# Altium schematic sheet Power.SchDoc
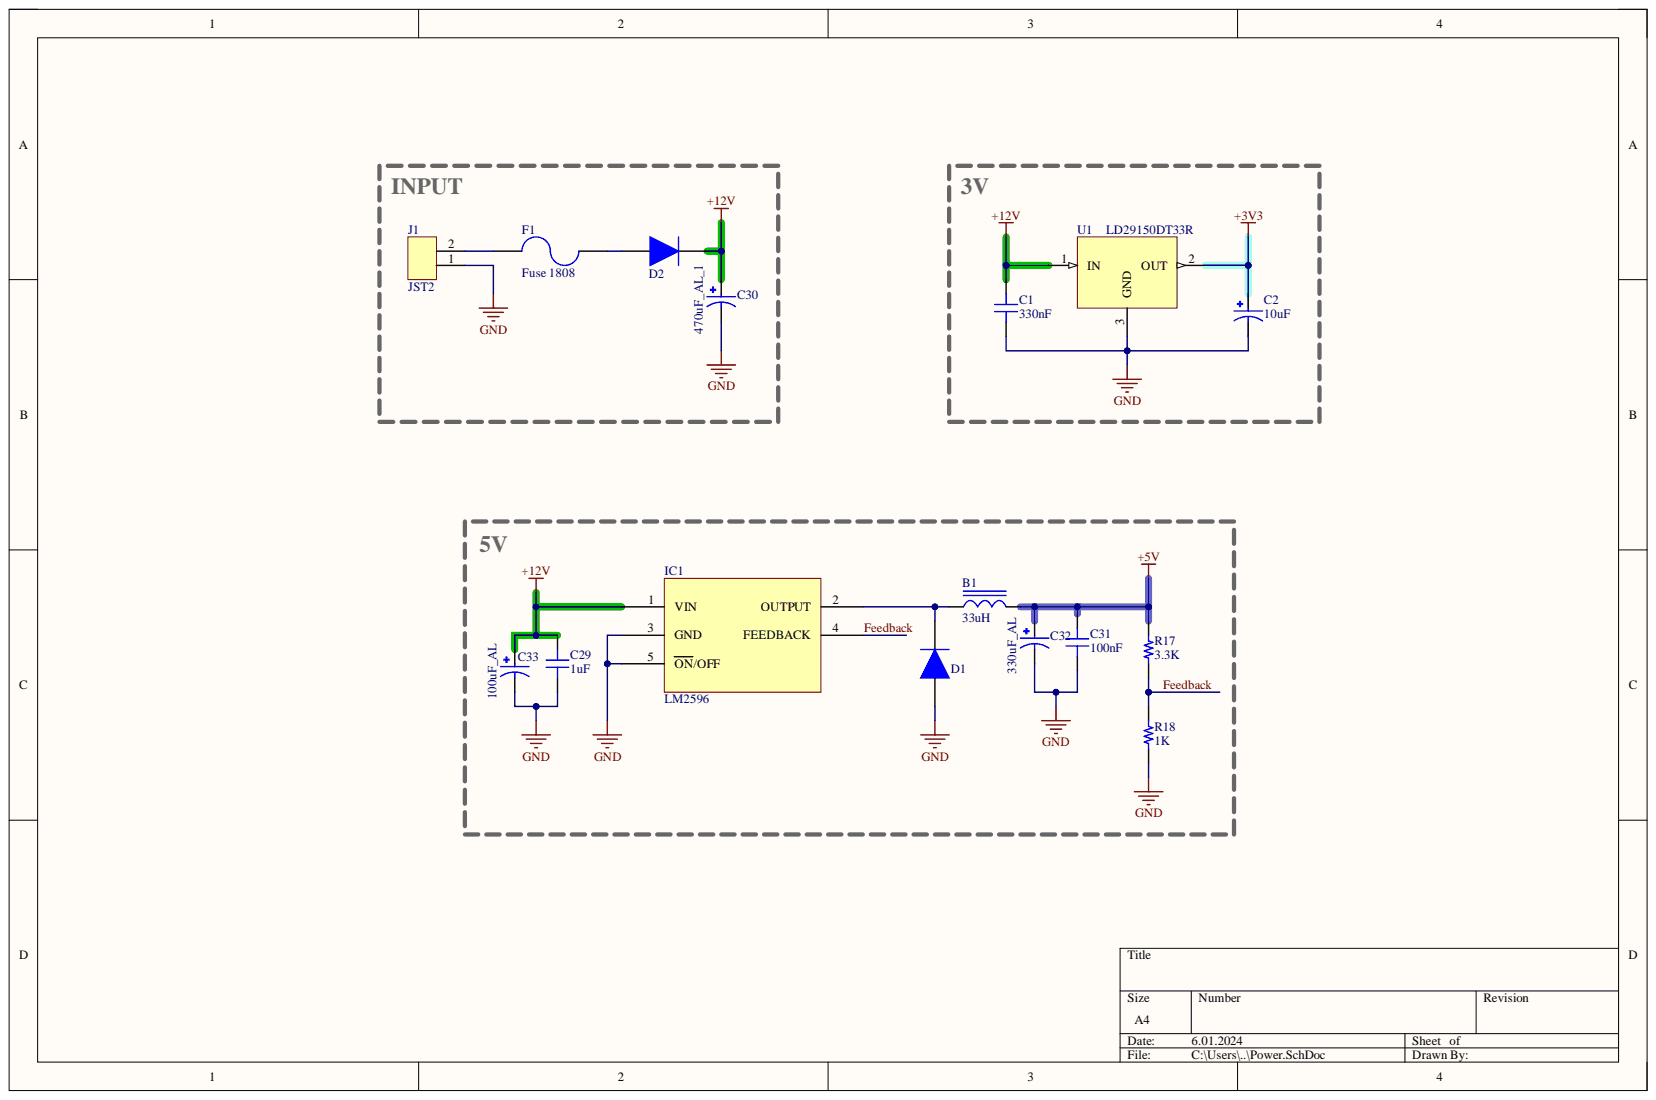
[diagram p0: Power.SchDoc]

## Parts
B1  33uH  [DR125]
C1  330nF  [CAP 0603_1608]
C2  10uF  [TANTAL B-CASE]
C29  1uF  [CAP 0603_1608]
C30  470uF_AL_1  [10x12 Al Cap]
C31  100nF  [CAP 0603_1608]
C32  330uF_AL  [10x12 Al Cap]
C33  100uF_AL  [10x12 Al Cap]
D1  1N4148WS  [SOD323F]  (value not drawn)
D2  1N4148WS  [SOD323F]  (value not drawn)
F1  Fuse 1808  [1808]
IC1  LM2596  [D2PAK]
J1  JST2  [JST2]
R17  3.3K  [RES 0603_1608]
R18  1K  [RES 0603_1608]
U1  LD29150DT33R  [TO252-3 DPAK]

## Nets
+3V3: C2.+ U1.2
+5V: B1.2 C31.2 C32.1 R17.2
+12V: C1.2 C29.2 C30.1 C33.1 D2.1 IC1.1(VIN) U1.1
GND: C1.1 C2.- C29.1 C30.2 C31.1 C32.2 C33.2 D1.2 IC1.3(GND) IC1.5(ON/OFF) J1.1 R18.1 U1.3
Feedback: IC1.4(FEEDBACK) R17.1 R18.2
NetB1_1: B1.1 D1.1 IC1.2(OUTPUT)
NetD2_2: D2.2 F1.2
NetF1_1: F1.1 J1.2

## Library data of the parts
B1 = 33uH: DR125; Component Kind: Standard; Library Name: hava_kalitesi_olcumu.SchLib
C1 = 330nF: CERAMİC 1206 SMD; Component Kind: Standard; Library Name: hava_kalitesi_olcumu.SchLib
C2 = 10uF: TANTAL; Component Kind: Standard; Library Name: hava_kalitesi_olcumu.SchLib
C29 = 1uF: CERAMİC 1206 SMD; Component Kind: Standard; Library Name: hava_kalitesi_olcumu.SchLib
C30 = 470uF_AL_1: Aluminium Electrolytic Capacitors; Component Kind: Standard; ComponentLink1Description: Vishay; ComponentLink1URL: https://www.mouser.com.tr/datasheet/2/427/136rvi-1762300.pdf; Library Name: hava_kalitesi_olcumu.SchLib
C31 = 100nF: CERAMİC 1206 SMD; Component Kind: Standard; Library Name: hava_kalitesi_olcumu.SchLib
C32 = 330uF_AL: Aluminium Electrolytic Capacitors; Component Kind: Standard; ComponentLink1Description: Vİshay; ComponentLink1URL: https://www.mouser.com.tr/datasheet/2/427/136rvi-1762300.pdf; Library Name: hava_kalitesi_olcumu.SchLib
C33 = 100uF_AL: Aluminium Electrolytic Capacitors; Component Kind: Standard; ComponentLink1Description: Vishay; ComponentLink1URL: https://www.mouser.com.tr/datasheet/2/427/136rvi-1762300.pdf; Library Name: hava_kalitesi_olcumu.SchLib
D1 D2 = 1N4148WS: Diode, Single, 75 V, 150 mA, 1 V, 4 ns; Manufacturer: ON Semiconductor / Fairchild; Average Rectified Current: 150mA; Forward Voltage: 1V; Max Forward Surge Current (Ifsm): 2A; Max Repetitive Reverse Voltage (Vrrm): 75V; Max Reverse Current: 5uA; Power Dissipation: 200mW; Recovery Time: 4ns; Category: Rectifier Diodes; Component Kind: Standard; ComponentLink1Description: https://datasheet.ciiva.com/25968/1n914bws-25968945.pdf?src-supplier=Avnet+Europe; ComponentLink1URL: https://datasheet.ciiva.com/25968/1n914bws-25968945.pdf?src-supplier=Avnet+Europe; ComponentLink2Description: https://datasheet.ciiva.com/30479/0900766b810e1cd3-30479206.pdf?src-supplier=RSComponents; ComponentLink2URL: https://datasheet.ciiva.com/30479/0900766b810e1cd3-30479206.pdf?src-supplier=RSComponents; ComponentLink3Description: https://datasheet.ciiva.com/910/1n4148ws-910381.pdf?src-supplier=Digi-Key; ComponentLink3URL: https://datasheet.ciiva.com/910/1n4148ws-910381.pdf?src-supplier=Digi-Key; ComponentLink4Description: https://datasheet.ciiva.com/15208/getdatasheetpartid-127819-15208358.pdf?src-supplier=Verical; ComponentLink4URL: https://datasheet.ciiva.com/15208/getdatasheetpartid-127819-15208358.pdf?src-supplier=Verical; ComponentLink5Description: https://datasheet.ciiva.com/8300/1n914bws-8300360.pdf?src-supplier=Avnet; ComponentLink5URL: https://datasheet.ciiva.com/8300/1n914bws-8300360.pdf?src-supplier=Avnet; ComponentLink6Description: https://datasheet.ciiva.com/910/1n4148ws-910380.pdf?src-supplier=Digi-Key; ComponentLink6URL: https://datasheet.ciiva.com/910/1n4148ws-910380.pdf?src-supplier=Digi-Key; ComponentLink7Description: https://datasheet.ciiva.com/910/1n4148ws-910382.pdf?src-supplier=Digi-Key; ComponentLink7URL: https://datasheet.ciiva.com/910/1n4148ws-910382.pdf?src-supplier=Digi-Key; Contact Plating: Tin,Matte; Element Configuration: Single; Forward Current: 150mA; Height: 1mm; Lead Free: Lead Free; Length: 1.8mm; Manufacturer Part Number: 1N4148WS; Max Operating Temperature: 150°C; Max Reverse Voltage (DC): 75V; Min Operating Temperature: -55°C; Mount: Surface Mount; Number of Pins: 2; Package Quantity: 1; Packaging: Tape and Reel; Pricing 1: 1=0,17000, 10=0,12100, 100=0,06530, 500=0,05128, 1000=0,03562 (USD); Radiation Hardening: No; REACH SVHC: No SVHC; Reverse Recovery Time: 4ns; Reverse Voltage: 75V; RoHS: Yes; RoHS Compliant: Yes; Series: -; Stock 1: 79118; Supplier 1: Digi-Key; Supplier Part Number 1: 1N4148WSFSCT-ND; Weight: 0.0276g; Width: 1.35mm; Library Name: hava_kalitesi_olcumu.SchLib
F1 = FUSE: FUSE BRD MNT 125VAC/VDC; Component Kind: Standard; ComponentLink1Description: Direnc.net; ComponentLink1URL: https://www.direnc.net/1a-smd-sigorta; ComponentLink2Description: Motorobit; ComponentLink2URL: https://www.motorobit.com/urun/1a-1808-kilif-smd-sigorta; ComponentLink3Description: Datasheet; ComponentLink3URL: https://www.littelfuse.com/~/media/electronics/datasheets/fuses/littelfuse_fuse_452_454_datasheet.pdf.pdf; ComponentLink4Description: Step; ComponentLink4URL: https://www.3dcontentcentral.com.tr/secure/download-model.aspx?catalogid=171&id=343808; ComponentLink5Description: Özdisan; ComponentLink5URL: https://www.ozdisan.com/devre-koruyucular/sigorta-bilesenleri/sigortalar/0454001-NR; Library Name: hava_kalitesi_olcumu.SchLib
IC1 = LM2596: Buck Converter; Component Kind: Standard; Library Name: hava_kalitesi_olcumu.SchLib
J1 = JST2: 2 PIN 2.54MM; Component Kind: Standard; Library Name: hava_kalitesi_olcumu.SchLib
R17 = 3.3K: SMT RES 1206; Component Kind: Standard; Library Name: hava_kalitesi_olcumu.SchLib
R18 = 1K: SMT RES 1206; Component Kind: Standard; Library Name: hava_kalitesi_olcumu.SchLib
U1 = LD29150: IC-29150 REG LDO 3V3 1.5A DPAK; Component Kind: Standard; ComponentLink1Description: Özdisan; ComponentLink1URL: https://ozdisan.com/entegre-devreler-ics/guc-entegreleri/lineer-voltaj-regulatorleri/LD29150DT33R; Library Name: hava_kalitesi_olcumu.SchLib
